# Altium schematic sheet PIC32ETH.SchDoc(PIC32ETH)
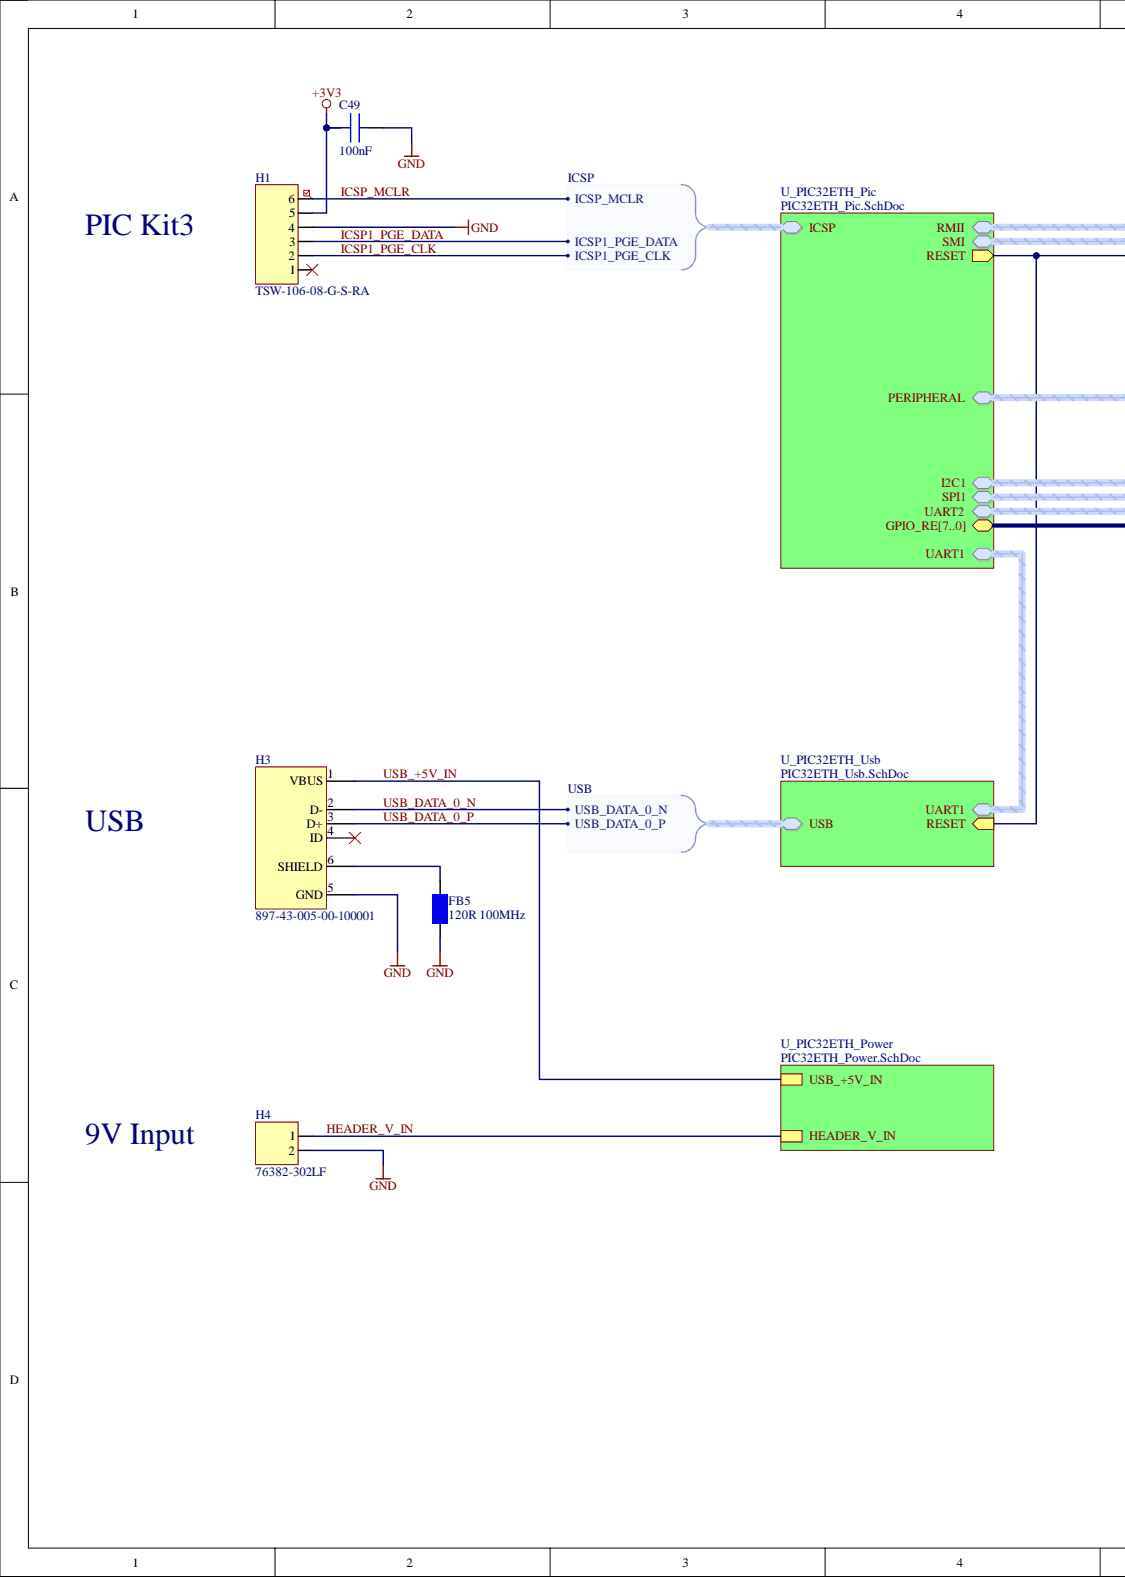
[diagram p0: PIC32ETH.SchDoc, left]
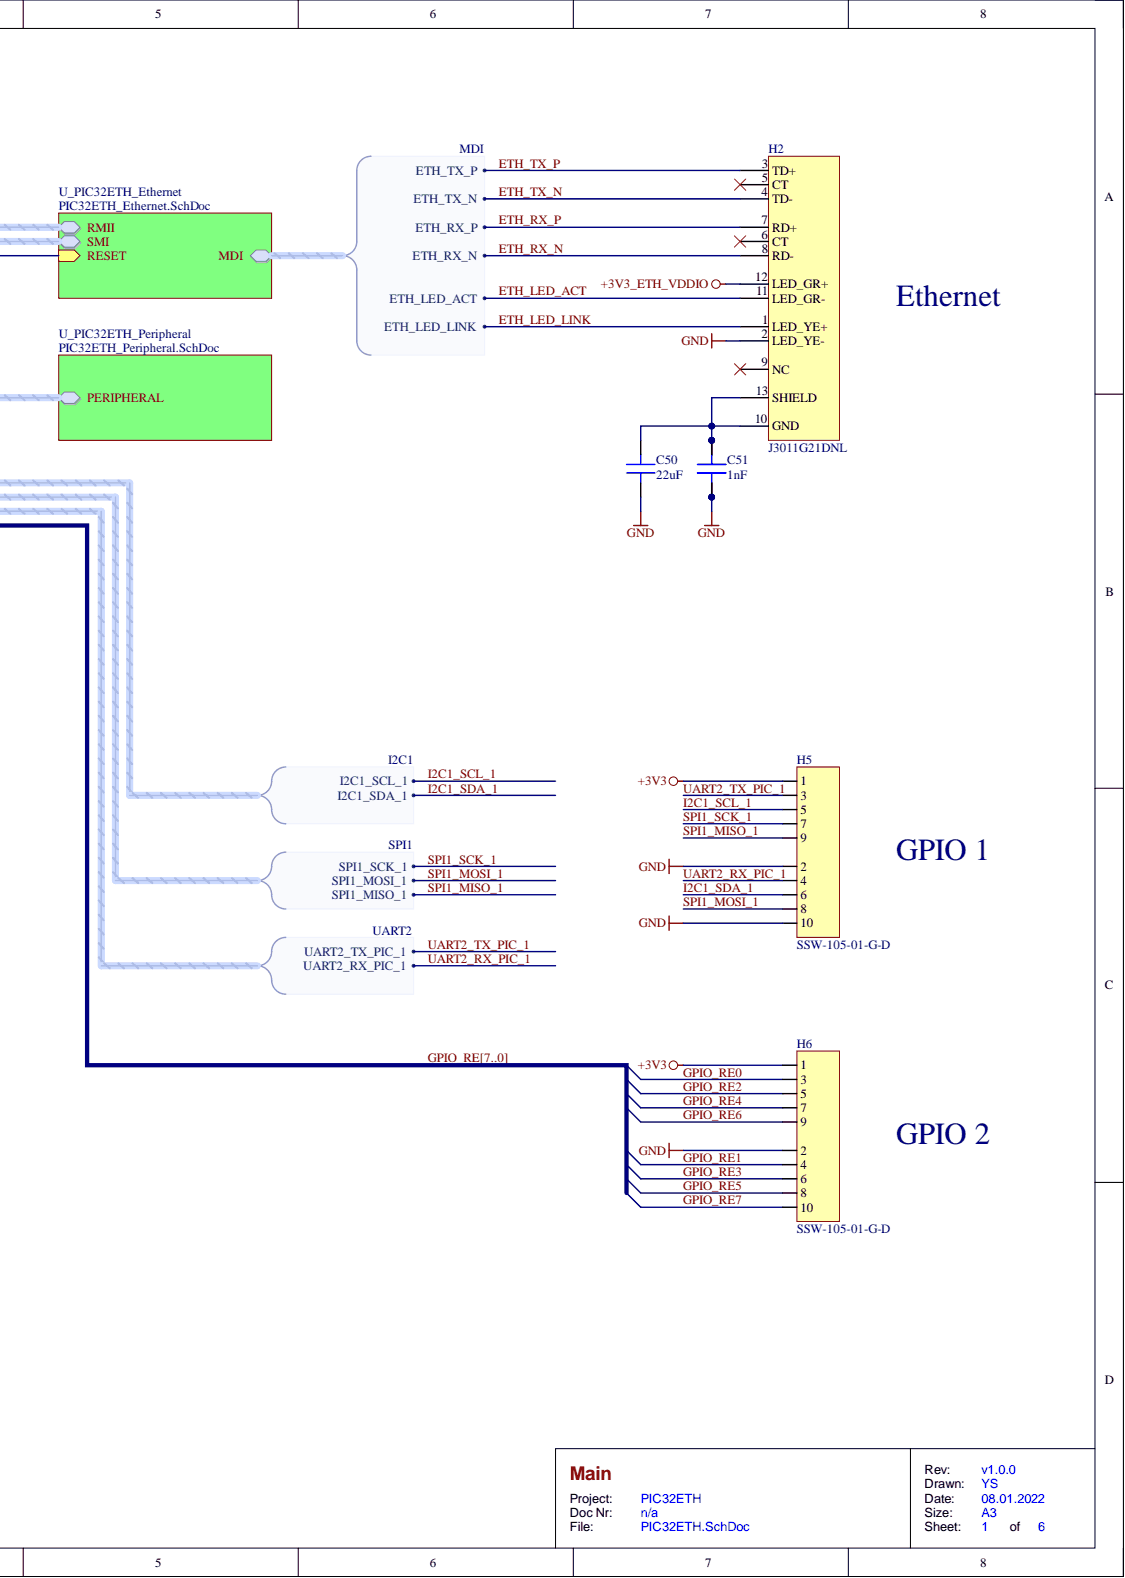
[diagram p1: PIC32ETH.SchDoc, right]

## Parts
C49  100nF  [C_CHIP2012N]
C50  22uF  [C_CHIP3216N]
C51  1nF  [C_CHIP2012N]
FB5  120R 100MHz  [FB_CHIP3216N]
H1  TSW-106-08-G-S-RA  [H_TSW-106-08-G-S-RA]
H2  J3011G21DNL  [H_J3011G21DNL]
H3  897-43-005-00-100001  [H_897-43-005-00-100001]
H4  76382-302LF  [H_76382-302LF]
H5  SSW-105-01-G-D  [H_SSW-105-01-G-D]
H6  SSW-105-01-G-D  [H_SSW-105-01-G-D]

## Nets
+3V3: C49.1 H1.5 H5.1 H6.1
+3V3_ETH_VDDIO: H2.12(LED_GR+)
GND: C49.2 C50.1 C51.1 FB5.2 H1.4 H2.2(LED_YE-) H3.5(GND) H4.2 H5.2 H5.10 H6.2
ETH_LED_ACT: H2.11(LED_GR-)
ETH_LED_LINK: H2.1(LED_YE+)
ETH_RX_N: H2.8(RD-)
ETH_RX_P: H2.7(RD+)
ETH_TX_N: H2.4(TD-)
ETH_TX_P: H2.3(TD+)
GPIO_RE0: H6.3  [also labelled GPIO_RE]
GPIO_RE1: H6.4  [also labelled GPIO_RE]
GPIO_RE2: H6.5  [also labelled GPIO_RE]
GPIO_RE3: H6.6  [also labelled GPIO_RE]
GPIO_RE4: H6.7  [also labelled GPIO_RE]
GPIO_RE5: H6.8  [also labelled GPIO_RE]
GPIO_RE6: H6.9  [also labelled GPIO_RE]
GPIO_RE7: H6.10  [also labelled GPIO_RE]
HEADER_V_IN: H4.1
I2C1_SCL_1: H5.5
I2C1_SDA_1: H5.6
ICSP1_PGE_CLK: H1.2
ICSP1_PGE_DATA: H1.3
ICSP_MCLR: H1.6
SPI1_MISO_1: H5.9
SPI1_MOSI_1: H5.8
SPI1_SCK_1: H5.7
UART2_RX_PIC_1: H5.4
UART2_TX_PIC_1: H5.3
USB_+5V_IN: H3.1(VBUS)
USB_DATA_0_N: H3.2(D-)
USB_DATA_0_P: H3.3(D+)
NetC50_2: C50.2 C51.2 H2.10(GND) H2.13(SHIELD)
NetFB5_1: FB5.1 H3.6(SHIELD)
NetH1_1: H1.1
NetH2_5: H2.5(CT)
NetH2_6: H2.6(CT)
NetH2_9: H2.9(NC)
NetH3_4: H3.4(ID)
N00002:
N00003:
N00004:
N00006:
N00007:
N00008:
N00009:
N00010:
N00011:
N00012:
N00013:
N00014:
N00015:
N00016:
N00017:
N00018:
N00019:
N00020:
N00021:

## Library data of the parts
C49 = C_C0805C104K5RACTU: C CERAMIC 100nF 50V 10% 2012; Manufacturer: Kemet; Dielectric: X7R; Tolerance: +/-10%; Capacitance: 100nF; Component Kind: Standard; Datasheet Url: https://content.kemet.com/datasheets/KEM_C1002_X7R_SMD.pdf; Esr: n/a; Library Name: PIC32ETH.SchLib; Mounting: SMT; Package: 2012; Part Number: C0805C104K5RACTU; Pin Count: 2; Price: 0.23; Price Currency: CHF; Supplier 1: Digi-Key; Supplier Part Number 1: 399-1170-1-ND; Temperature Range: -55degC to 125degC; Voltage: 50V
C50 = C_C1206C226K8RAC7800: C CERAMIC 22uF 10V 10% 3216; Manufacturer: Kemet; Dielectric: X7R; Tolerance: +/-10%; Capacitance: 22uF; Component Kind: Standard; Datasheet Url: https://api.kemet.com/component-edge/download/datasheet/C1206C226K8RAC7800.pdf; Esr: n/a; Library Name: PIC32ETH.SchLib; Mounting: SMT; Package: 3216; Part Number: C1206C226K8RAC7800; Pin Count: 2; Price: 0.65; Price Currency: CHF; Supplier 1: Digi-Key; Supplier Part Number 1: 399-11947-1-ND; Temperature Range: -55degC to 125degC; Voltage: 10V
C51 = C_C0805C102K5RACTU: C CERAMIC 1nF 50V 10% 2012; Manufacturer: Kemet; Dielectric: X7R; Tolerance: +/-10%; Capacitance: 1nF; Component Kind: Standard; Datasheet Url: https://content.kemet.com/datasheets/KEM_C1002_X7R_SMD.pdf; Esr: n/a; Library Name: PIC32ETH.SchLib; Mounting: SMT; Package: 2012; Part Number: C0805C102K5RACTU; Pin Count: 2; Price: 0.11; Price Currency: CHF; Supplier 1: Digi-Key; Supplier Part Number 1: 399-1147-1-ND; Temperature Range: -55degC to 125degC; Voltage: 50V
FB5 = FB_BLM31KN121SN1L: FB 120R 100MHz 6A 3216; Manufacturer: Murata Electronics; Resistance: 9mR; Component Kind: Standard; Current: 6A; Datasheet Url: https://www.murata.com/en-us/products/productdata/8801529331742/ENFA0091.pdf; Impedance: 120R 100MHz; Library Name: PIC32ETH.SchLib; Mounting: SMT; Package: 3216; Part Number: BLM31KN121SN1L; Pin Count: 2; Price: 0.29; Price Currency: CHF; Supplier 1: Digi-Key; Supplier Part Number 1: 490-16524-1-ND; Temperature Range: -55degC to 125degC
H1 = H_TSW-106-08-G-S-RA: H GENERAL HEADER 6POS 1ROW 2.54mm ANGLE THT; Manufacturer: Samtec Inc.; Current: 5.7A; Positions: 6; Rows: 1; Voltage: 550VAC, 770VDC; Component Kind: Standard; Datasheet URL: http://suddendocs.samtec.com/catalog_english/tsw_th.pdf; Header Type: Header; Library Name: PIC32ETH.SchLib; Mating Cycles: n/a; Mounting: THT; Orientation: Angle; Package: n/a; Part Number: TSW-106-08-G-S-RA; Pin Count: 6; Price: 0.68; Price Currency: CHF; Spacing: 2.54mm; Supplier 1: Digi-Key; Supplier Part Number 1: SAM1039-06-ND; Temperature Range: -55degC to 125degC
H2 = H_J3011G21DNL: H RJ45 SOCKET 1PORT ANGLE SMT; Manufacturer: Pulse; Ports: 1; Component Kind: Standard; Current: n/a; Datasheet URL: https://productfinder.pulseeng.com/doc_type/WEB301/doc_num/J409/doc_part/J409.pdf; Header Type: Socket; Library Name: PIC32ETH.SchLib; Mating Cycles: n/a; Mounting: SMT; Orientation: Angle; Package: n/a; Part Number: J3011G21DNL; Pin Count: 13; Price: 8.68; Price Currency: CHF; Supplier 1: Digi-Key; Supplier Part Number 1: 553-1491-ND; Temperature Range: 0°C to 70°C; Voltage: n/a
H3 = H_897-43-005-00-100001: H USB SOCKET 1PORT B-MINI ANGLE SMT; Manufacturer: Mill-Max Manufacturing Corp.; Current: 1A; Mating Cycles: 5000; Ports: 1; Voltage: 30VAC; Component Kind: Standard; Datasheet URL: http://www.farnell.com/datasheets/1734713.pdf?_ga=2.223747291.1932968036.1561574157-1154557334.1561574157&_gac=1.227804655.1561574157.CjwKCAjwr8zoBRA0EiwANmvpYNQHn877f_ACzLNOCpO9cVZHUYePGKu6J6mtcTAYQ9aGUVPIBV0ilRoC6_EQAvD_BwE; Header Type: Socket; Library Name: PIC32ETH.SchLib; Mounting: SMT; Orientation: Angle; Package: n/a; Part Number: 897-43-005-00-100001; Pin Count: 6; Price: 1.21; Price Currency: CHF; Supplier 1: Digi-Key; Supplier Part Number 1: ED90341CT-ND; Temperature Range: n/a; Usb Type: B-Mini
H4 = H_76382-302LF: H GENERAL HEADER 2POS 1ROW 2.54mm ANGLE THT; Manufacturer: Amphenol ICC; Current: 3A; Mating Cycles: 1000; Positions: 2; Rows: 1; Voltage: 1000V; Component Kind: Standard; Datasheet URL: https://cdn.amphenol-icc.com/media/wysiwyg/files/drawing/76382.pdf; Header Type: Header; Library Name: PIC32ETH.SchLib; Mounting: THT; Orientation: Angle; Package: n/a; Part Number: 76382-302LF; Pin Count: 2; Price: 0.71; Price Currency: CHF; Spacing: 2.54mm; Supplier 1: Digi-Key; Supplier Part Number 1: 609-1278-ND; Temperature Range: -40degC to 125degC
H5 H6 = H_SSW-105-01-G-D: H GENERAL SOCKET 10POS 2ROW 2.54mm VERTICAL THT; Manufacturer: Samtec Inc.; Current: 5.7A; Positions: 10; Rows: 2; Voltage: 465VAC, 655VDC; Component Kind: Standard; Datasheet URL: http://suddendocs.samtec.com/catalog_english/ssq_th.pdf; Header Type: Socket; Library Name: PIC32ETH.SchLib; Mating Cycles: n/a; Mounting: THT; Orientation: Vertical; Package: n/a; Part Number: SSW-105-01-G-D; Pin Count: 10; Price: 1.33; Price Currency: CHF; Spacing: 2.54mm; Supplier 1: Digi-Key; Supplier Part Number 1: SAM1208-05-ND; Temperature Range: -55degC to 125degC
